# Altium schematic sheet telemetry_adc.SchDoc
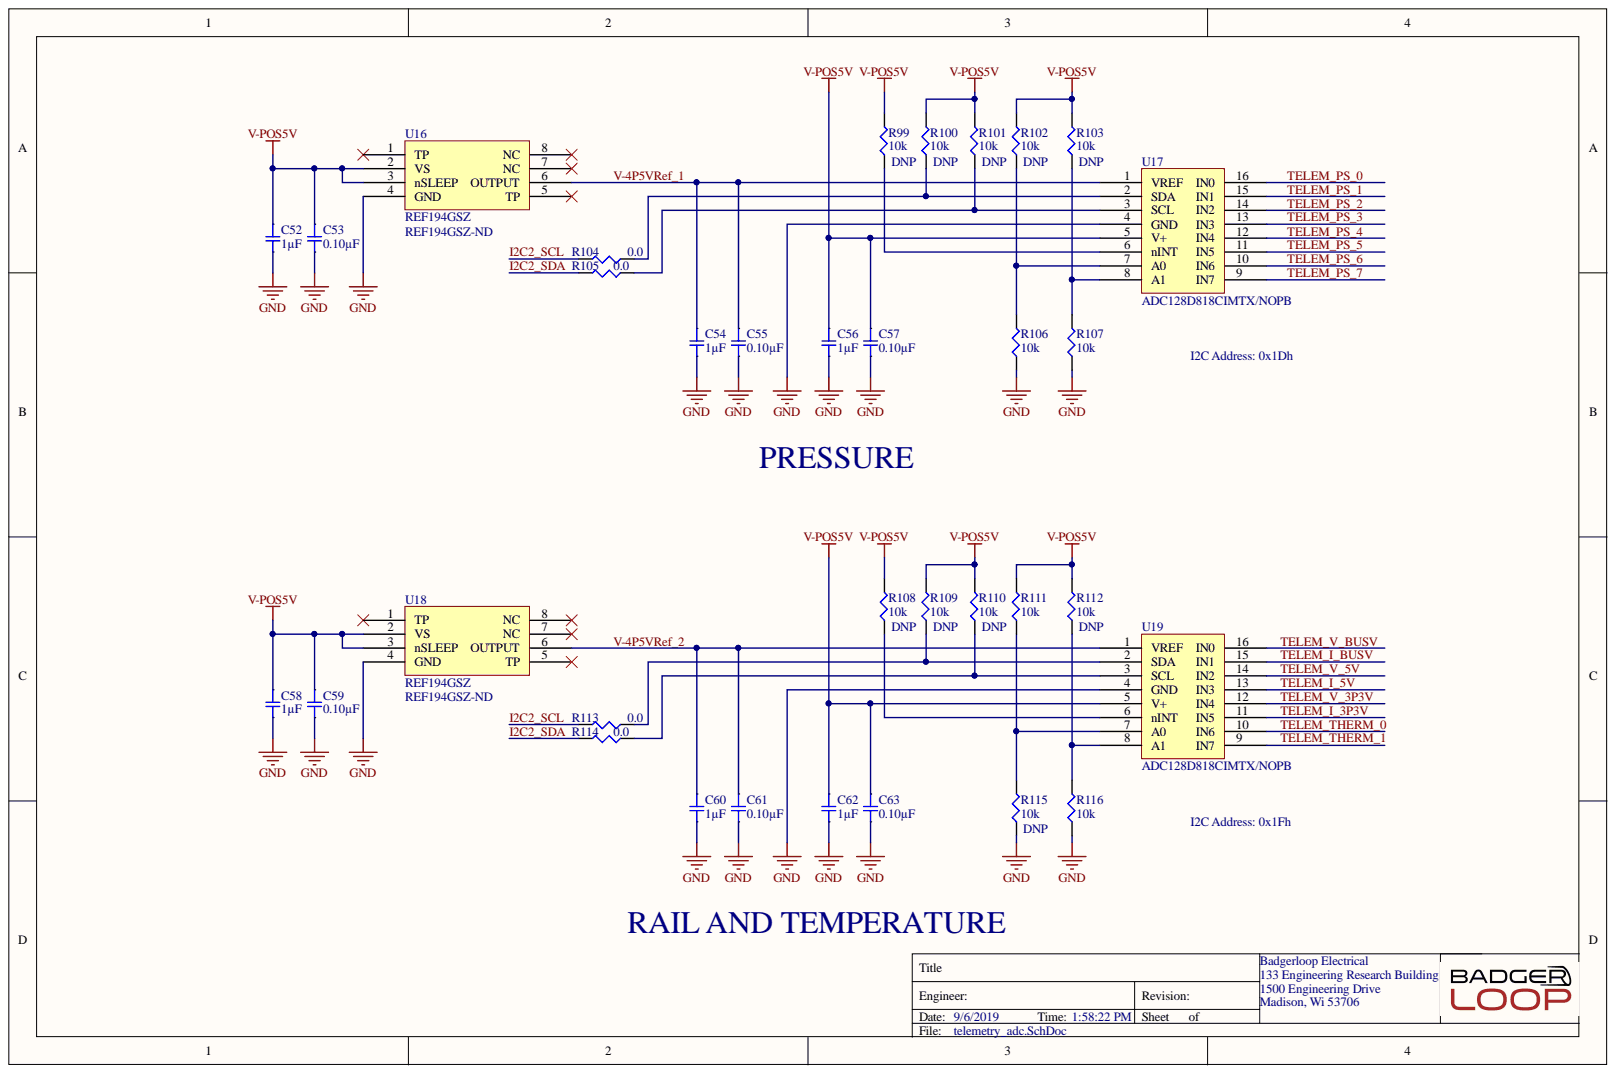
[diagram p0: telemetry_adc.SchDoc]

## Parts
C52  1µF  [SMD-0805C]
C53  0.10µF  [SMD-0805C]
C54  1µF  [SMD-0805C]
C55  0.10µF  [SMD-0805C]
C56  1µF  [SMD-0805C]
C57  0.10µF  [SMD-0805C]
C58  1µF  [SMD-0805C]
C59  0.10µF  [SMD-0805C]
C60  1µF  [SMD-0805C]
C61  0.10µF  [SMD-0805C]
C62  1µF  [SMD-0805C]
C63  0.10µF  [SMD-0805C]
R99  10k  [SMD-0805-RES]
R100  10k  [SMD-0805-RES]
R101  10k  [SMD-0805-RES]
R102  10k  [SMD-0805-RES]
R103  10k  [SMD-0805-RES]
R104  0.0  [SMD-0805-RES]
R105  0.0  [SMD-0805-RES]
R106  10k  [SMD-0805-RES]
R107  10k  [SMD-0805-RES]
R108  10k  [SMD-0805-RES]
R109  10k  [SMD-0805-RES]
R110  10k  [SMD-0805-RES]
R111  10k  [SMD-0805-RES]
R112  10k  [SMD-0805-RES]
R113  0.0  [SMD-0805-RES]
R114  0.0  [SMD-0805-RES]
R115  10k  [SMD-0805-RES]
R116  10k  [SMD-0805-RES]
U16  REF194GSZ  Supplier Part Number 1: REF194GSZ-ND  [SOP127P600X175-8N]
U17  ADC128D818CIMTX/NOPB
U18  REF194GSZ  Supplier Part Number 1: REF194GSZ-ND  [SOP127P600X175-8N]
U19  ADC128D818CIMTX/NOPB

## Nets
GND: C52.2 C53.2 C54.2 C55.2 C56.2 C57.2 C58.2 C59.2 C60.2 C61.2 C62.2 C63.2 R106.1 R107.1 R115.1 R116.1 U16.4(GND) U17.4(GND) U18.4(GND) U19.4(GND)
V-POS5V: C52.1 C53.1 C56.1 C57.1 C58.1 C59.1 C62.1 C63.1 R99.2 R100.2 R101.2 R102.2 R103.2 R108.2 R109.2 R110.2 R111.2 R112.2 U16.2(VS) U16.3(nSLEEP) U17.5(V+) U18.2(VS) U18.3(nSLEEP) U19.5(V+)
I2C2_SCL: R104.2 R113.2
I2C2_SDA: R105.2 R114.2
TELEM_I_3P3V: U19.11(IN5)
TELEM_I_5V: U19.13(IN3)
TELEM_I_BUSV: U19.15(IN1)
TELEM_PS_0: U17.16(IN0)
TELEM_PS_1: U17.15(IN1)
TELEM_PS_2: U17.14(IN2)
TELEM_PS_3: U17.13(IN3)
TELEM_PS_4: U17.12(IN4)
TELEM_PS_5: U17.11(IN5)
TELEM_PS_6: U17.10(IN6)
TELEM_PS_7: U17.9(IN7)
TELEM_THERM_0: U19.10(IN6)
TELEM_THERM_1: U19.9(IN7)
TELEM_V_3P3V: U19.12(IN4)
TELEM_V_5V: U19.14(IN2)
TELEM_V_BUSV: U19.16(IN0)
V-4P5VRef_1: C54.1 C55.1 U16.6(OUTPUT) U17.1(VREF)
V-4P5VRef_2: C60.1 C61.1 U18.6(OUTPUT) U19.1(VREF)
NetR99_1: R99.1 U17.6(nINT)
NetR100_1: R100.1 R104.1 U17.2(SDA)
NetR101_1: R101.1 R105.1 U17.3(SCL)
NetR102_1: R102.1 R106.2 U17.7(A0)
NetR103_1: R103.1 R107.2 U17.8(A1)
NetR108_1: R108.1 U19.6(nINT)
NetR109_1: R109.1 R113.1 U19.2(SDA)
NetR110_1: R110.1 R114.1 U19.3(SCL)
NetR111_1: R111.1 R115.2 U19.7(A0)
NetR112_1: R112.1 R116.2 U19.8(A1)

Not connected: U16 1(TP) 5(TP) 7(NC) 8(NC); U18 1(TP) 5(TP) 7(NC) 8(NC)

## Library data of the parts
C52 C54 C56 C58 C60 C62 = GRM21BR71H105KA12L: CAP CER 1UF 50V X7R 0805; Manufacturer: Murata Electronics North America; @ qty: 0; Minimum Quantity: 1; Tolerance: ±10%; Unit Price (USD): 0.25; Applications: General Purpose; Component Kind: Standard; Datasheets: http://www.murata.com/~/media/webrenewal/support/library/catalog/products/capacitor/mlcc/c02e.pdf; Digi-Key Part Number: 490-4736-1-ND; Factory Stock: 0; Features: -; Height - Seated (Max): -; Image: //media.digikey.com/Renders/Murata%20Renders/0805%20(2012%20Metric)%20.85mm.jpg; Lead Spacing: -; Lead Style: -; Library Name: capacitors.SchLib; Mounting Type: Surface Mount, MLCC; Operating Temperature: -55°C ~ 125°C; Package / Case: 0805 (2012 Metric); Packaging: Cut Tape (CT); Part Number: GRM21BR71H105KA12L; Part Status: Active; Quantity Available: 988032; Ratings: -; Series: GRM; Size / Dimension: 0.079" L x 0.049" W (2.00mm x 1.25mm); Temperature Coefficient: X7R; Thickness (Max): 0.053" (1.35mm); Value: 1µF; Voltage: 50V
C53 C55 C57 C59 C61 C63 = GCD21BR71H104KA01L: CAP CER 0.1UF 50V X7R 0805; Manufacturer: Murata Electronics North America; @ qty: 0; Minimum Quantity: 1; Tolerance: ±10%; Unit Price (USD): 0.24; Applications: Automotive; Component Kind: Standard; Datasheets: http://www.murata.com/~/media/webrenewal/support/library/catalog/products/capacitor/mlcc/c03e.ashx; Digi-Key Part Number: 490-11955-1-ND; Factory Stock: 0; Features: -; Height - Seated (Max): -; Image: //media.digikey.com/Renders/Murata%20Renders/0805%28GxM6%29.jpg; Lead Spacing: -; Lead Style: -; Library Name: capacitors.SchLib; Mounting Type: Surface Mount, MLCC; Operating Temperature: -55°C ~ 125°C; Package / Case: 0805 (2012 Metric); Packaging: Cut Tape (CT); Part Number: GCD21BR71H104KA01L; Part Status: Active; Quantity Available: 157; Ratings: AEC-Q200; Series: GCD; Size / Dimension: 0.079" L x 0.049" W (2.00mm x 1.25mm); Temperature Coefficient: X7R; Thickness (Max): 0.049" (1.25mm); Value: 0.10µF; Voltage: 50V
R99-R103 R106-R112 R115 R116 = ERJ-P06J103V: RES SMD 10K OHM 5% 1/2W 0805; Manufacturer: Panasonic Electronic Components; @ qty: 1500; Factory Stock: 0; Minimum Quantity: 1; Power (Watts): 0.5W, 1/2W; Temperature Coefficient: ±200ppm/°C; Unit Price (USD): 0.01839; Component Kind: Standard; Composition: Thick Film; Datasheets: https://industrial.panasonic.com/cdbs/www-data/pdf/RDA0000/AOA0000C243.pdf; Digi-Key Part Number: P10KADCT-ND; Failure Rate: -; Features: Automotive AEC-Q200, Pulse Withstanding; Height - Seated (Max): 0.028" (0.70mm); Image: //media.digikey.com/Renders/Panasonic%20Renders/ERJ-0805%20Pkg.jpg; Library Name: resistors.SchLib; Number of Terminations: 2; Operating Temperature: -55°C ~ 155°C; Package / Case: 0805 (2012 Metric); Packaging: Cut Tape (CT); Part Number: ERJ-P06J103V; Part Status: Active; Quantity Available: 413576; Series: ERJ; Size / Dimension: 0.079" L x 0.049" W (2.00mm x 1.25mm); Supplier Device Package: 0805; Tolerance: ±5%; Value: 10k
  R99-R103 R108-R110 R112 R115: DNP: DNP
R104 R105 R113 R114 = ERJ-6GEY0R00V: RES SMD 0.0 OHM JUMPER 1/8W 0805; Manufacturer: Panasonic Electronic Components; @ qty: 1500; Factory Stock: 0; Minimum Quantity: 1; Power (Watts): 0.125W, 1/8W; Unit Price (USD): 0.00544; Component Kind: Standard; Composition: Thick Film; Datasheets: http://industrial.panasonic.com/www-cgi/jvcr13pz.cgi?E+PZ+3+AOA0001+ERJ6GEY0R00V+7+WW; Digi-Key Part Number: P0.0ACT-ND; Failure Rate: -; Features: Automotive AEC-Q200; Height - Seated (Max): 0.028" (0.70mm); Image: //media.digikey.com/Renders/Panasonic%20Renders/ERJ-0805%20Pkg.jpg; Library Name: resistors.SchLib; Number of Terminations: 2; Operating Temperature: -55°C ~ 155°C; Package / Case: 0805 (2012 Metric); Packaging: Cut Tape (CT); Part Number: ERJ-6GEY0R00V; Part Status: Active; Quantity Available: 1597627; Series: ERJ; Size / Dimension: 0.079" L x 0.049" W (2.00mm x 1.25mm); Supplier Device Package: 0805; Temperature Coefficient: -; Tolerance: Jumper; Value: 0.0
U16 U18 = REF194GSZ: IC VREF SERIES 4.5V 8SOIC; Manufacturer: Analog Devices; Max Input Voltage: 18V; Max Output Current: 30mA; Min Input Voltage: 4.75V; Min Output Voltage: 4.49V; Nominal Supply Current: 45uA; Number of Channels: 1; Quiescent Current: 15uA; Temperature Coefficient: 25ppm/°C; Case/Package: R; Component Kind: Standard; ComponentLink1Description: https://datasheet.ciiva.com/17219/ref19xseries-17219157.pdf?src-supplier=Avnet+Europe; ComponentLink1URL: https://datasheet.ciiva.com/17219/ref19xseries-17219157.pdf?src-supplier=Avnet+Europe; ComponentLink2Description: https://datasheet.ciiva.com/22666/1506113-22666153.pdf?src-supplier=Element14; ComponentLink2URL: https://datasheet.ciiva.com/22666/1506113-22666153.pdf?src-supplier=Element14; ComponentLink3Description: https://datasheet.ciiva.com/2005/ref19xseries-2005902.pdf?src-supplier=Digi-Key; ComponentLink3URL: https://datasheet.ciiva.com/2005/ref19xseries-2005902.pdf?src-supplier=Digi-Key; ComponentLink4Description: https://datasheet.ciiva.com/3235/ref19xseries-3235518.pdf?src-supplier=Avnet; ComponentLink4URL: https://datasheet.ciiva.com/3235/ref19xseries-3235518.pdf?src-supplier=Avnet; Height: 1.5mm; Lead Free: Contains Lead; Length: 5mm; Library Name: ic.SchLib; Manufacturer Part Number: REF194GSZ; Max Operating Temperature: 85°C; Max Output Voltage: 4.5V; Min Operating Temperature: -40°C; Number of Pins: 8; Operating Supply Current: 45uA; Output Current: 30mA; Output Voltage: 4.5V; Package Quantity: 98; Packaging: Rail/Tube; Pricing 1: 1=3.56000, 10=3.19700, 25=3.02240, 100=2.61940, 250=2.48512, 500=2.22988, 1000=1.88062, 2500=1.78659 (USD); Radiation Hardening: No; REACH SVHC: No SVHC; Reference Type: Series; Reference Voltage: 4.5V; RoHS: Yes; Stock 1: 359; Supplier 1: Digi-Key; Width: 4mm
U17 U19 = ADC128D818CIMTX/NOPB: Analog to Digital Converters - ADC; Manufacturer: TI National Semiconductor; Differential Nonlinearity: 1LSB; Integral Nonlinearity (INL): -2LSB; Interface: I2C; Max Power Dissipation: 33mW; Max Supply Voltage: 5.5V; Min Supply Voltage: 3V; Number of A/D Converters: 1; Number of Bits: 12; Number of Channels: 8; Number of Converters: 4; Polarity: Unipolar; Power Consumption: 2mW; Power Dissipation: 2.01mW; Resolution: 12b; Sampling Rate: 10000S/s; Supply Type: Analog,Digital,Single; Case/Package: TSSOP; Component Kind: Standard; ComponentLink1Description: https://datasheet.ciiva.com/14259/adc128d818-14259977.pdf?src-supplier=Avnet; ComponentLink1URL: https://datasheet.ciiva.com/14259/adc128d818-14259977.pdf?src-supplier=Avnet; Contact Plating: Tin,Matte; Lead Free: Lead Free; Library Name: ic.SchLib; Manufacturer Part Number: ADC128D818CIMTX/NOPB; Max Operating Temperature: 125°C; Min Operating Temperature: -40°C; Mount: Surface Mount; Number of Elements: 1; Number of Inputs: 8; Number of Pins: 16; Package Quantity: 2500; Packaging: Tape and Reel; Pricing 1: 1=4.68000, 10=4.20700, 100=3.44660, 500=2.93402, 1000=2.47447 (USD); Radiation Hardening: No; RoHS: Yes; Stock 1: 21177; Supplier 1: Digi-Key; Supplier Part Number 1: 296-41182-1-ND
